# Altium schematic sheet Microprocessor and CAN.SchDoc
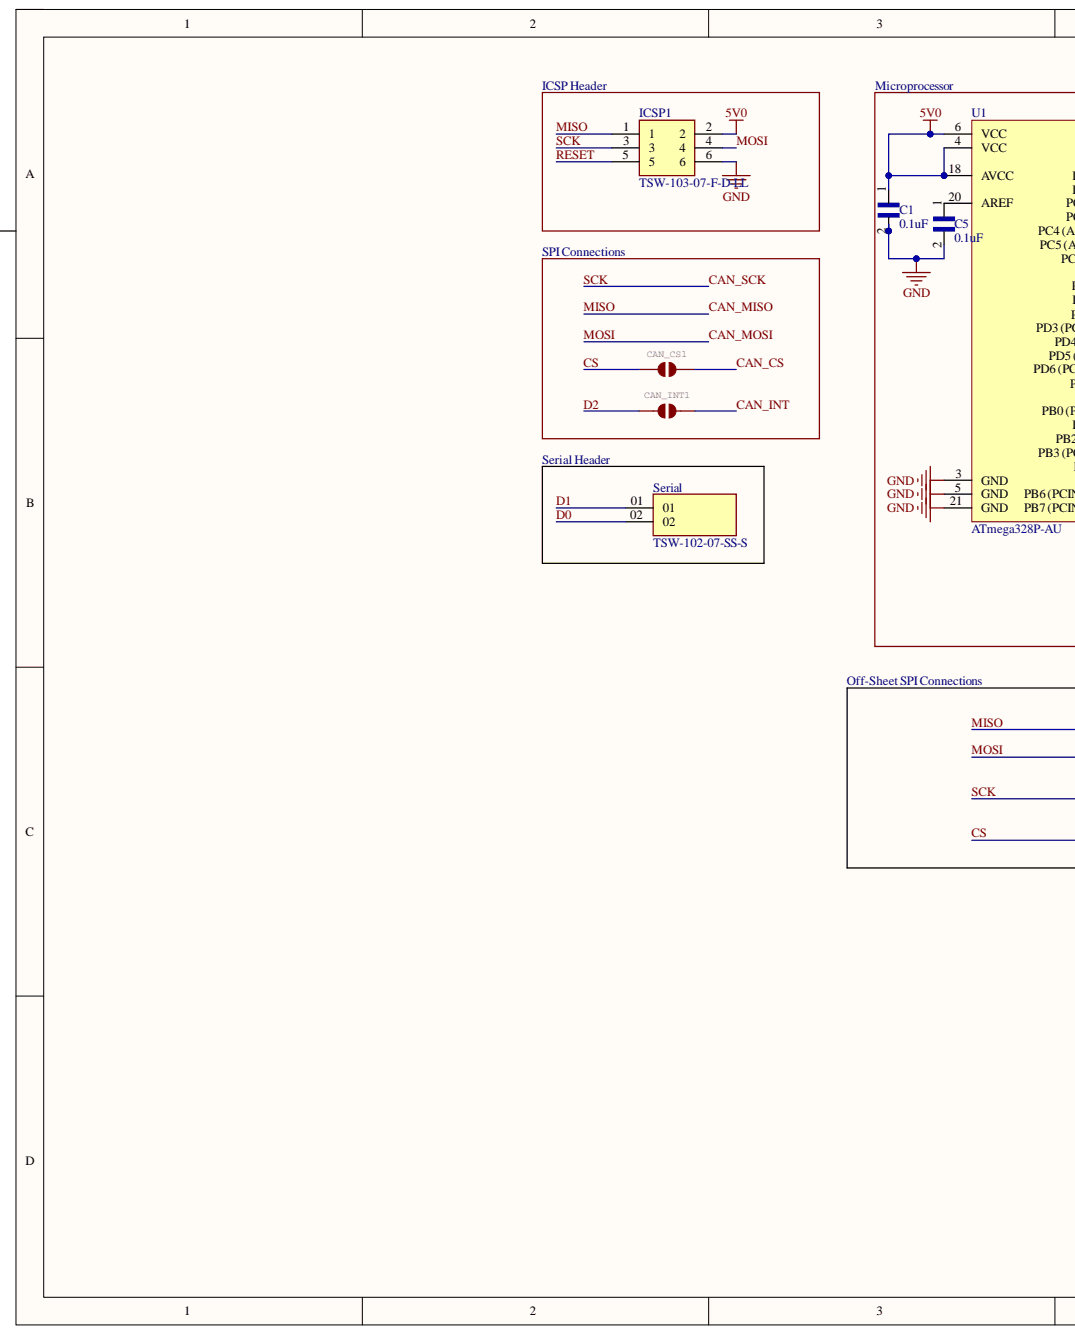
[diagram p0: Microprocessor and CAN.SchDoc, left]
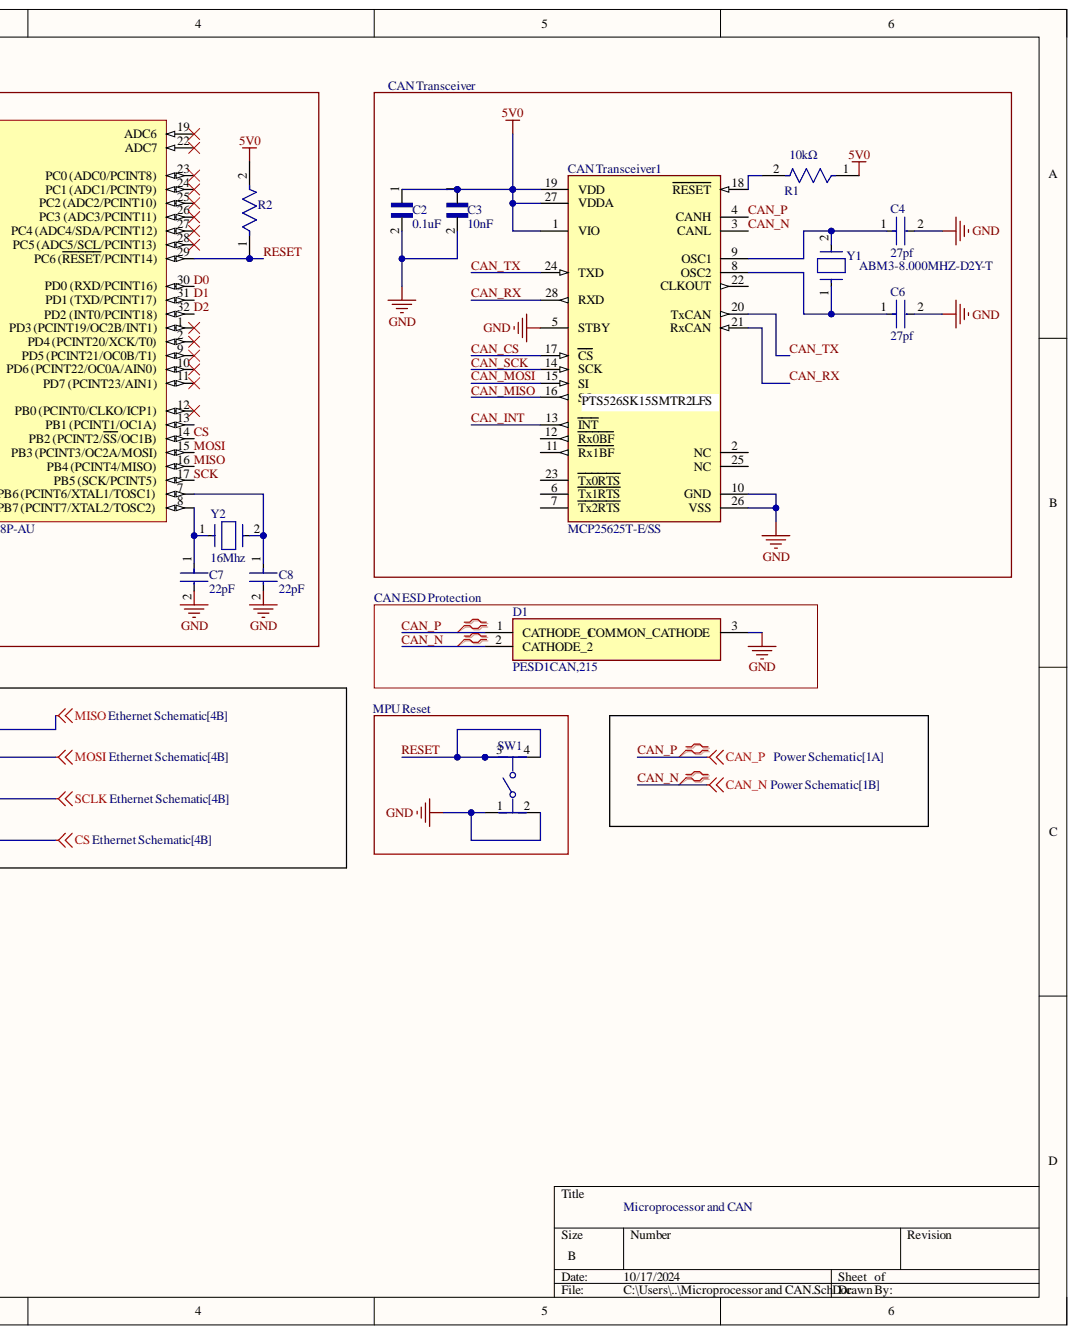
[diagram p1: Microprocessor and CAN.SchDoc, right]

## Parts
C1  0.1uF  [CAPC2012X135N]
C2  0.1uF  [CAPC2012X135N]
C3  10nF  [CAPC2012X88N]
C4  27pf  [FP-GRM21B-0_15-IPC_C]
C5  0.1uF  [CAPC2012X135N]
C6  27pf  [FP-GRM21B-0_15-IPC_C]
C7  22pF  [FP-C0805C-DN-MFG]
C8  22pF  [FP-C0805C-DN-MFG]
CAN_Transceiver1  MCP25625T-E/SS  [FP-C04-073-IPC_B]
CAN_CS1  (no value)  [SMT-JUMPER_2_NO_SILK]
CAN_INT1  (no value)  [SMT-JUMPER_2_NO_SILK]
D1  PESD1CAN,215  [SOT95P230X110-3N]
ICSP1  TSW-103-07-F-D-LL  [SAMTEC_TSW-103-07-S-D-LL]
R1  10kΩ  [FP-CRCW0805-e3-IPC_A]
R2  CRCW080510K0FKEA  [FP-CRCW0805-e3-IPC_A]  (value not drawn)
Serial  TSW-102-07-SS-S  [SAMTEC_TSW-102-07-SS-S]
SW1  PTS526SK15SMTR2LFS  [FP-PTS526SK15SMTR2LFS-MFG]
U1  ATmega328P-AU  [32A_M]
Y1  ABM3-8.000MHZ-D2Y-T  [FP-ABM3-MFG]
Y2  16Mhz  [FP-ABM3-MFG]

## Nets
5V0: C1.1 C2.1 C3.1 CAN_Transceiver1.1(VIO) CAN_Transceiver1.19(VDD) CAN_Transceiver1.27(VDDA) ICSP1.2 R1.1 R2.2 U1.4(VCC) U1.6(VCC) U1.18(AVCC)
GND: C1.2 C2.2 C3.2 C4.2 C5.2 C6.2 C7.2 C8.2 CAN_Transceiver1.5(STBY) CAN_Transceiver1.10(GND) CAN_Transceiver1.26(VSS) D1.3 ICSP1.6 SW1.1 SW1.2 U1.3(GND) U1.5(GND) U1.21(GND)
CAN_CS: CAN_Transceiver1.17(CS) CAN_CS1.2
CAN_INT: CAN_Transceiver1.13(INT) CAN_INT1.2
CAN_MISO: CAN_Transceiver1.16(SO) ICSP1.1 U1.16(PB4_(PCINT4/MISO))
CAN_MOSI: CAN_Transceiver1.15(SI) ICSP1.4 U1.15(PB3_(PCINT3/OC2A/MOSI))
CAN_N: CAN_Transceiver1.3(CANL) D1.2
CAN_P: CAN_Transceiver1.4(CANH) D1.1
CAN_RX: CAN_Transceiver1.21(RxCAN) CAN_Transceiver1.28(RXD)
CAN_SCK: CAN_Transceiver1.14(SCK) ICSP1.3 U1.17(PB5_(SCK/PCINT5))
CAN_TX: CAN_Transceiver1.20(TxCAN) CAN_Transceiver1.24(TXD)
CS: CAN_CS1.1 U1.14(PB2_(PCINT2/SS/OC1B))
D0: Serial.02 U1.30(PD0_(RXD/PCINT16))
D1: Serial.01 U1.31(PD1_(TXD/PCINT17))
D2: CAN_INT1.1 U1.32(PD2_(INT0/PCINT18))
RESET: ICSP1.5 R2.1 SW1.3 SW1.4 U1.29(PC6_(RESET/PCINT14))
NetC4_1: C4.1 CAN_Transceiver1.9(OSC1) Y1.2
NetC5_1: C5.1 U1.20(AREF)
NetC6_1: C6.1 CAN_Transceiver1.8(OSC2) Y1.1
NetC7_1: C7.1 U1.8 Y2.1
NetC8_1: C8.1 U1.7 Y2.2
NetCAN Transceiver1_18: CAN_Transceiver1.18(RESET) R1.2

Not connected: CAN_Transceiver1 2(NC) 6(Tx1RTS) 7(Tx2RTS) 11(Rx1BF) 12(Rx0BF) 22(CLKOUT) 23(Tx0RTS) 25(NC); U1 1(PD3_(PCINT19/OC2B/INT1)) 2(PD4_(PCINT20/XCK/T0)) 9(PD5_(PCINT21/OC0B/T1)) 10(PD6_(PCINT22/OC0A/AIN0)) 11(PD7_(PCINT23/AIN1)) 12(PB0_(PCINT0/CLKO/ICP1)) 13(PB1_(PCINT1/OC1A)) 19(ADC6) 22(ADC7) 23(PC0_(ADC0/PCINT8)) 24(PC1_(ADC1/PCINT9)) 25 26(PC3_(ADC3/PCINT11)) 27(PC4_(ADC4/SDA/PCINT12)) 28(PC5_(ADC5/SCL/PCINT13))

## Library data of the parts
C1 C2 C5 = GRM21BR72A104JAC4K: CAP 0.1UF 100VDC X7R 5% SMD 0805 EMBOSSED TAPE; Availability: Unavailable; Component Kind: Standard; Library Name: GRM21BR72A104JAC4K.IntLib; MP: GRM21BR72A104JAC4K; Package: None; Price: None; Supplier 1: Mouser; Supplier Part Number 1: 81-GRM21BR72A104JA4K
C3 = C0805C103F5GACTU: Cap Ceramic 0.01uF 50V C0G 1% SMD 0805 125Â°C Paper T/R; MF: KEMET; Component Kind: Standard; Digi-Key_Part_Number: 399-11161-1-ND; Digi-Key_Purchase_URL: https://www.digikey.com/product-detail/en/kemet/C0805C103F5GACTU/399-11161-1-ND/4357871?utm_source=snapeda&utm_medium=aggregator&utm_campaign=symbol; Library Name: C0805C103F5GACTU.IntLib; MP: C0805C103F5GACTU; Package: 0805 KEMET; SnapEDA_Link: https://www.snapeda.com/parts/C0805C103F5GACTU/KEMET/view-part/1836651/?ref=snap; Supplier 1: Mouser; Supplier Part Number 1: 80-C0805C103F5G
C4 C6 = CMP-2007-03541-2: Chip Multilayer Ceramic Capacitors for General Purpose, 0805, 10uF, X5R, 15%, 10%, 25V; Capacitance: 10uF; Case Code (Imperial): 0805; Case Code (Metric): 2012; Case/Package: 0805; Component Kind: Standard; Depth: 1.25mm; Dielectric: X5R; Height: 1.25mm; Lead Free: Lead Free; Length: 2mm; Library Name: Altium Content Vault; Material: Ceramic; Max Operating Temperature: 85°C; Min Operating Temperature: -55°C; Mount: Surface Mount; Package Quantity: 1; Packaging: Tape and Reel; Radiation Hardening: No; Termination: SMD/SMT; Thickness: 0.053inch; Tolerance: 10%; Voltage: 25V; Voltage Rating: 25V; Voltage Rating (DC): 25V; Width: 0.049inch
C7 C8 = CMP-2007-00048-2: Capacitor; Ceramic; Cap; 22.0pF; Tol 5%; SMT; Vol-Rtg 50V; C0G; Tape and Reel; Manufacturer: KEMET; Manufacturer Part Number: C0805C220J5GACTU; Temperature Coefficient: 30ppm/°C; Tolerance: 0.05; Automotive: No; Capacitance: 22 pF; Category: Cap; Component Kind: Standard; ComponentLink2Description: Datasheet; ComponentLink2URL: https://content.kemet.com/datasheets/KEM_C1003_C0G_SMD.pdf; Device Class L1: Passive Components; Device Class L2: Capacitors; Device Class L3: Ceramic Capacitors; Digikey Description: Capacitor; Ceramic; Cap; 22.0pF; Tol 5%; SMT; Vol-Rtg 50V; C0G; Tape and Reel; Height: 0.88mm; Ipc Land Pattern Name: CAPC200125X78; Lead Free: Yes; Library Name: Altium Content Vault; Material: Ceramic; Mouser Description: Multilayer Ceramic Capacitors MLCC - SMD/SMT 50volts 22pF C0G 5%; Octopart: https://octopart.com/c0805c220j5gactu-kemet-145470; Package: C0805C-DN; RoHS: TRUE; Temperature: -55°C to +125°C; Temperature Characteristic: C0G; Temperature Range High: +125°C; Temperature Range Low: -55°C; Value: 22 pF; Voltage Rating: 50 V
CAN_Transceiver1 = CMP-06279-000016-1: 1/1 Transceiver CANbus 28-SSOP; Data Rate: 1Mbps; Interface: SPI; Max Supply Voltage: 5.5V; Min Supply Voltage: 4.5V; Number of Drivers: 1; Operating Supply Current: 70mA; Propagation Delay: 125ns; Receiver Hysteresis: 200mV; Case/Package: SSOP; Component Kind: Standard; Footprint 1: 28-SSOP; Library Name: Altium Content Vault; Manufacturer PN: MCP25625T-E/SS; Max Operating Temperature: 125°C; Min Operating Temperature: -40°C; Mount: Surface Mount; Number of Pins: 28; Number of Receivers: 1; Number of Transceivers: 1; Package Quantity: 2100; Packaging: Tape and Reel; RoHS Compliant: Yes; Weight: 0.080001oz
CAN_CS1 CAN_INT1 = JUMPER-SMT_2_NO_SILK: DeviceName: _SILK; GateName_1: G$1; SymbolName_1: SMT-JUMPER_2_NO; Component Kind: Standard; DeviceSetName: JUMPER-SMT_2_NO; Library Name: SparkFun-Jumpers.SCHLIB; LibraryName: SparkFun-Jumpers; Package: SMT-JUMPER_2_NO_SILK
D1 = PESD1CAN,215: Diode ESD (Uni-directional); Manufacturer_Name: Nexperia; Arrow Part Number: PESD1CAN,215; Arrow Price/Stock: https://www.arrow.com/en/products/pesd1can215/nexperia?region=nac; Component Kind: Standard; Datasheet Link: https://assets.nexperia.com/documents/data-sheet/PESD1CAN.pdf; Height: 1.1mm; Manufacturer_Part_Number: PESD1CAN,215; Mouser Part Number: 771-PESD1CAN-T/R; Mouser Price/Stock: https://www.mouser.co.uk/ProductDetail/Nexperia/PESD1CAN215?qs=LOCUfHb8d9vzREMT8aTFcw%3D%3D; Supplier 1: Future Electronics; Supplier Part Number 1: 6297154
ICSP1 = TSW-103-07-F-D-LL: Connector; Manufacturer_Name: SAMTEC; Arrow Part Number: TSW-103-07-F-D-LL; Arrow Price/Stock: https://www.arrow.com/en/products/tsw-103-07-f-d-ll/samtec?utm_currency=USD&region=nac; Component Kind: Standard; Datasheet Link: http://suddendocs.samtec.com/catalog_english/tsw_th.pdf; Height: 8.38mm; Manufacturer_Part_Number: TSW-103-07-F-D-LL; Mouser Part Number: 200-TSW10307FDLL; Mouser Price/Stock: https://www.mouser.co.uk/ProductDetail/Samtec/TSW-103-07-F-D-LL?qs=Cqqh%252BS766wnDZazf78ytbg%3D%3D; Supplier 1: Arrow Electronics; Supplier Part Number 1: TSW-103-07-G-D
R1 R2 = CMP-2001-04908-2: RES Thick Film, 10kΩ, 1%, 0.125W, 100ppm/°C, 0805; Power Rating: 125mW; Resistance: 10kR; Voltage Rating: 150V; Case Code (Imperial): 0805; Case Code (Metric): 2012; Case/Package: 0805; Component Kind: Standard; Composition: Thick Film; Contact Plating: Tin; Depth: 1.25mm; Height: 0.45mm; Lead Free: Lead Free; Length: 2mm; Library Name: Altium Content Vault; Max Operating Temperature: 155°C; Military Standard: Not; Min Operating Temperature: -55°C; Package Quantity: 5000; Packaging: Tape and Reel; Radiation Hardening: No; Temperature Coefficient: 100ppm/°C; Termination: SMD/SMT; Tolerance: 1%; Voltage Rating (DC): 150V; Weight: 0.000194oz; Width: 1.25mm
Serial = TSW-102-07-SS-S: MF: {'manufacturer': u'Samtec'}; Availability: Unavailable; Component Kind: Standard; MP: TSW-102-07-SS-S; Package: None; Price: None
SW1 = CMP-10040-000013-1: TACT 5.2 X 5.2, 1.5 MM H, 260GF,; Manufacturer: C&K; Contact Current Rating: 50mA; Contact Resistance: 100mΩ; Electromechanical Life: 200000Cycles; Operating Force: 250gf; Standoff Height: 0mm; Throw Configuration: SPST-NO; Voltage Rating Dc: 12V; Automotive: No; Category: Switch; Component Kind: Standard; ComponentLink2Description: Datasheet; ComponentLink2URL: https://www.ckswitches.com/media/2780/pts526.pdf; Device Class L1: Electromechanical; Device Class L2: Switches; Device Class L3: Tactile Switches; Digikey Description: TACT 5.2 X 5.2, 1.5 MM H, 260GF,; Height: 1.65mm; Lead Free: Yes; Library Name: Altium Content Vault; Manufacturer Part Number: PTS526SK15SMTR2LFS; Octopart: https://octopart.com/pts526+sk15+smtr2+lfs-c%26k+components-100617999; Package: PTS526SK15SMTR2LFS; RoHS: TRUE; Temperature: -20°C to +70°C; Temperature Range High: +70°C; Temperature Range Low: -20°C
U1 = CMP-0095-00269-2: 8-bit AVR Microcontroller, 32KB Flash, 1KB EEPROM, 2KB SRAM, 32-pin TQFP, Industrial Grade (-40°C to 85°C); Code_JEDEC: MS-026-ABA; Component Kind: Standard; ComponentLink1Description: Manufacturer URL; ComponentLink1URL: http://www.atmel.com/; ComponentLink2Description: ATmega48A/48PA/88A/88PA/168A/168PA/328/328P Datasheet; ComponentLink2URL: http://www.atmel.com/dyn/resources/prod_documents/doc8271.pdf; ComponentLink3Description: ATmega48A/48PA/88A/88PA/168A/168PA/328/328P Summary; ComponentLink3URL: http://www.atmel.com/dyn/resources/prod_documents/8271S.pdf; DatasheetVersion: revD, May-2011; Library Name: Altium Content Vault; PackageDescription: 32-Lead, 7x7x1.0 mm Body, 0.8mm Lead Pitch, Thin Profile Plastic Quad Flat Package (TQFP); PackageReference: 32A; PackageVersion: revC, Oct-2010; RevisionNote: DatasheetVersion & PackageVersion updated. URLs added.
Y1 = CMP-2000-05033-2: Crystal 8MHz ±20ppm 18pF SMD-2 5mm x 3.2mm; ESR (Equivalent Series Resistance): 140R; Frequency Stability: 30ppm; Series Resistance: 500R; Case/Package: CSMD; Component Kind: Standard; Depth: 3.2mm; Frequency: 8MHz; Frequency Tolerance: 20ppm; Height: 0.051inch; Lead Free: Lead Free; Length: 5mm; Library Name: Altium Content Vault; Load Capacitance: 18pF; Max Operating Temperature: 85°C; Min Operating Temperature: -40°C; Mount: Surface Mount; Number of Pins: 2; Package Quantity: 1000; Packaging: Tape and Reel; Radiation Hardening: No; Resistor Type: Ceramic; RoHS Compliant: Yes; Termination: SMD/SMT; Tolerance: 20ppm; Width: 3.2mm
Y2 = CMP-27762-004490-1: Crystal 16MHz ±20ppm 18pF SMD-2 5mm x 3.2mm; ESR (Equivalent Series Resistance): 40R; Frequency Stability: 30ppm; Case/Package: SMD/SMT; Component Kind: Standard; Frequency: 16MHz; Frequency Tolerance: 20ppm; Height: 0.051inch; Lead Free: Lead Free; Length: 0.197inch; Library Name: Altium Content Vault; Load Capacitance: 18pF; Max Operating Temperature: 85°C; Min Operating Temperature: -40°C; Mount: Surface Mount; Number of Pins: 2; Operating Frequency: 16 MHz; Package Quantity: 1000; Packaging: Tape and Reel; Resistor Type: Ceramic; RoHS Compliant: Yes; Series: ABM3; Series Resistance: 40R; Termination: SMD/SMT; Tolerance: 20ppm; Width: 0.126inch
